# Altium schematic sheet nand.SchDoc(nand)
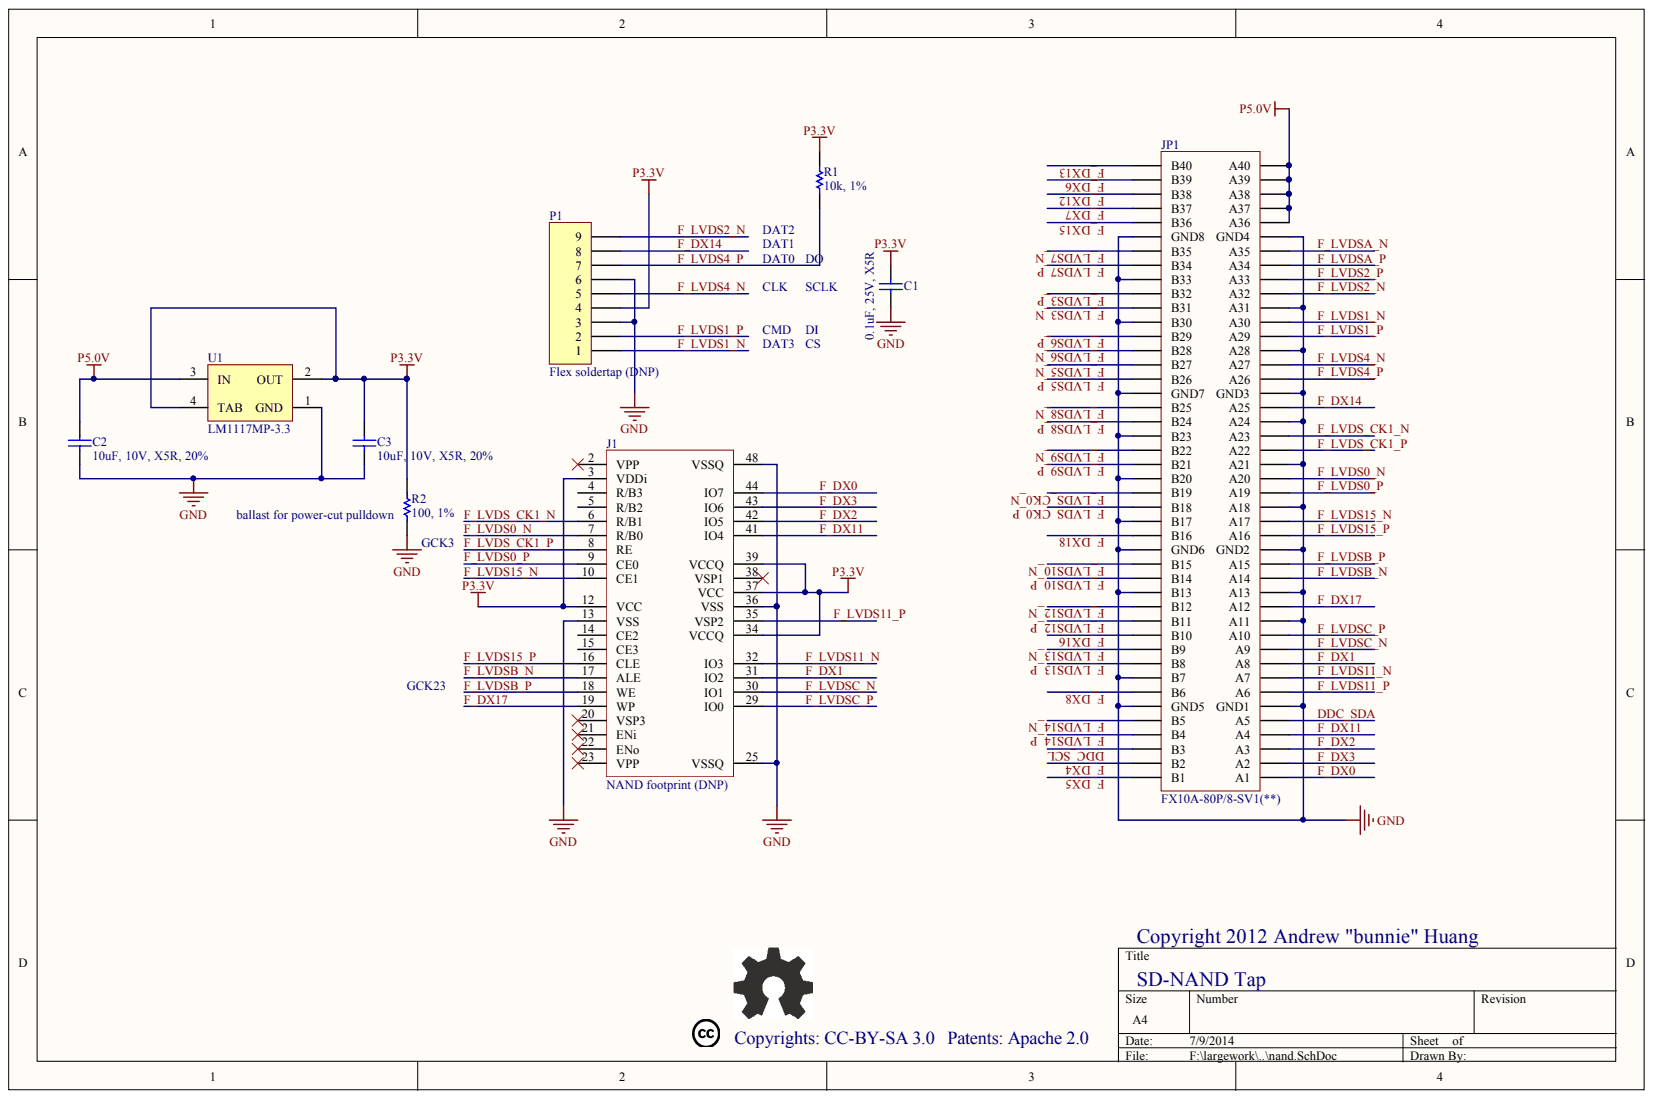
[diagram p0: nand.SchDoc]

## Parts
C1  0.1uF, 25V, X5R
C2  10uF, 10V, X5R, 20%
C3  10uF, 10V, X5R, 20%
J1  NAND footprint (DNP)
JP1  FX10A-80P/8-SV1(**)
P1  Flex soldertap (DNP)
R1  10k, 1%
R2  100, 1%
U1  LM1117MP-3.3

## Nets
GND: C1.2 C2.2 C3.2 J1.13(VSS) J1.25(VSSQ) J1.36(VSS) J1.48(VSSQ) JP1.A11 JP1.A13 JP1.A18 JP1.A21 JP1.A24 JP1.A28 JP1.A31 JP1.B7 JP1.B13 JP1.B17 JP1.B20 JP1.B23 JP1.B30 JP1.B33 JP1.GND1 JP1.GND2 JP1.GND3 JP1.GND4 JP1.GND5 JP1.GND6 JP1.GND7 JP1.GND8 P1.3 P1.6 R2.2 U1.1(GND)
P3.3V: C1.1 C3.1 J1.3(VDDi) J1.12(VCC) J1.34(VCCQ) J1.37(VCC) J1.39(VCCQ) P1.4 R1.1 R2.1 U1.2(OUT) U1.4(TAB)
P5.0V: C2.1 JP1.A36 JP1.A37 JP1.A38 JP1.A39 JP1.A40 U1.3(IN)
DDC_SCL: JP1.B3
DDC_SDA: JP1.A5
F_DX0: J1.44(IO7) JP1.A1
F_DX1: J1.31(IO2) JP1.A8
F_DX2: J1.42(IO5) JP1.A3
F_DX3: J1.43(IO6) JP1.A2
F_DX4: JP1.B2
F_DX5: JP1.B1
F_DX6: JP1.B39
F_DX7: JP1.B37
F_DX8: JP1.B6
F_DX11: J1.41(IO4) JP1.A4
F_DX12: JP1.B38
F_DX13: JP1.B40
F_DX14: JP1.A25 P1.8
F_DX15: JP1.B36
F_DX16: JP1.B10
F_DX17: J1.19(WP) JP1.A12
F_DX18: JP1.B16
F_LVDS0_N: J1.7(R/B0) JP1.A20
F_LVDS0_P: J1.9(CE0) JP1.A19
F_LVDS1_N: JP1.A30 P1.1
F_LVDS1_P: JP1.A29 P1.2
F_LVDS2_N: JP1.A32 P1.9
F_LVDS2_P: JP1.A33
F_LVDS3_N: JP1.B31
F_LVDS3_P: JP1.B32
F_LVDS4_N: JP1.A27 P1.5
F_LVDS4_P: JP1.A26 P1.7 R1.2
F_LVDS5_N: JP1.B27
F_LVDS5_P: JP1.B26
F_LVDS6_N: JP1.B28
F_LVDS6_P: JP1.B29
F_LVDS7_N: JP1.B35
F_LVDS7_P: JP1.B34
F_LVDS8_N: JP1.B25
F_LVDS8_P: JP1.B24
F_LVDS9_N: JP1.B22
F_LVDS9_P: JP1.B21
F_LVDS10_N: JP1.B15
F_LVDS10_P: JP1.B14
F_LVDS11_N: J1.32(IO3) JP1.A7
F_LVDS11_P: J1.35(VSP2) JP1.A6
F_LVDS12_N: JP1.B12
F_LVDS12_P: JP1.B11
F_LVDS13_N: JP1.B9
F_LVDS13_P: JP1.B8
F_LVDS14_N: JP1.B5
F_LVDS14_P: JP1.B4
F_LVDS15_N: J1.10(CE1) JP1.A17
F_LVDS15_P: J1.16(CLE) JP1.A16
F_LVDS_CK0_N: JP1.B19
F_LVDS_CK0_P: JP1.B18
F_LVDS_CK1_N: J1.6(R/B1) JP1.A23
F_LVDS_CK1_P: J1.8(RE) JP1.A22
F_LVDSA_N: JP1.A35
F_LVDSA_P: JP1.A34
F_LVDSB_N: J1.17(ALE) JP1.A14
F_LVDSB_P: J1.18(WE) JP1.A15
F_LVDSC_N: J1.30(IO1) JP1.A9
F_LVDSC_P: J1.29(IO0) JP1.A10

Not connected: J1 2(VPP) 4(R/B3) 5(R/B2) 14(CE2) 15(CE3) 20(VSP3) 21(ENi) 22(ENo) 23(VPP) 38(VSP1)

## Library data of the parts
R1 R2 = (no symbol name): Value: 100k; Published: 8-Jun-2000; LatestRevisionDate: 17-Jul-2002; LatestRevisionNote: Re-released for DXP Platform.; PackageReference: AXIAL-0.3; Publisher: Altium Limited
U1 = (no symbol name): Manufacturer: National Semiconductor; CompType: LDO IC; PackageTopMarking: XYTT*N05A; PowerWise: N; Published: 4-Dec-2008; DatasheetVersion: Apr-2006; PackageVersion: revB, Apr-2003; PackageReference: MP04A; PackageDescription: Molded SOT-223, 6.50 x 3.56 x 1.6mm Body, 4 Lead, 2.3mm Pitch; PartNumber: LM1117MP-3.3; RoHS: O; PinCount: 4; DigiKeyPartNumber: LM1117MP-3.3CT-ND; Code_JEDEC: TO-261-AA; Code_IPC: SOT223-4; ComponentLink1Description: LM1117 Datasheet; ComponentLink1URL: http://www.national.com/ds/LM/LM1117.pdf; ComponentLink2Description: LM1117 Web Page; ComponentLink2URL: http://www.national.com/pf/LM/LM1117.html; ComponentLink3Description: MP04A Package Page; ComponentLink3URL: http://www.national.com/packaging/folders/mp04a.html; Publisher: Altium Limited
C1-C3 J1 JP1 P1 = (no symbol name)
  C1-C3: Published: 8-Jun-2000; LatestRevisionDate: 17-Jul-2002; LatestRevisionNote: Re-released for DXP Platform.; PackageReference: C1206
  P1: LatestRevisionDate: 17-Jul-2002; LatestRevisionNote: Re-released for DXP Platform.
  C1-C3 P1: Publisher: Altium Limited
